# Altium schematic sheet sensor-6.SchDoc(sensor-6)
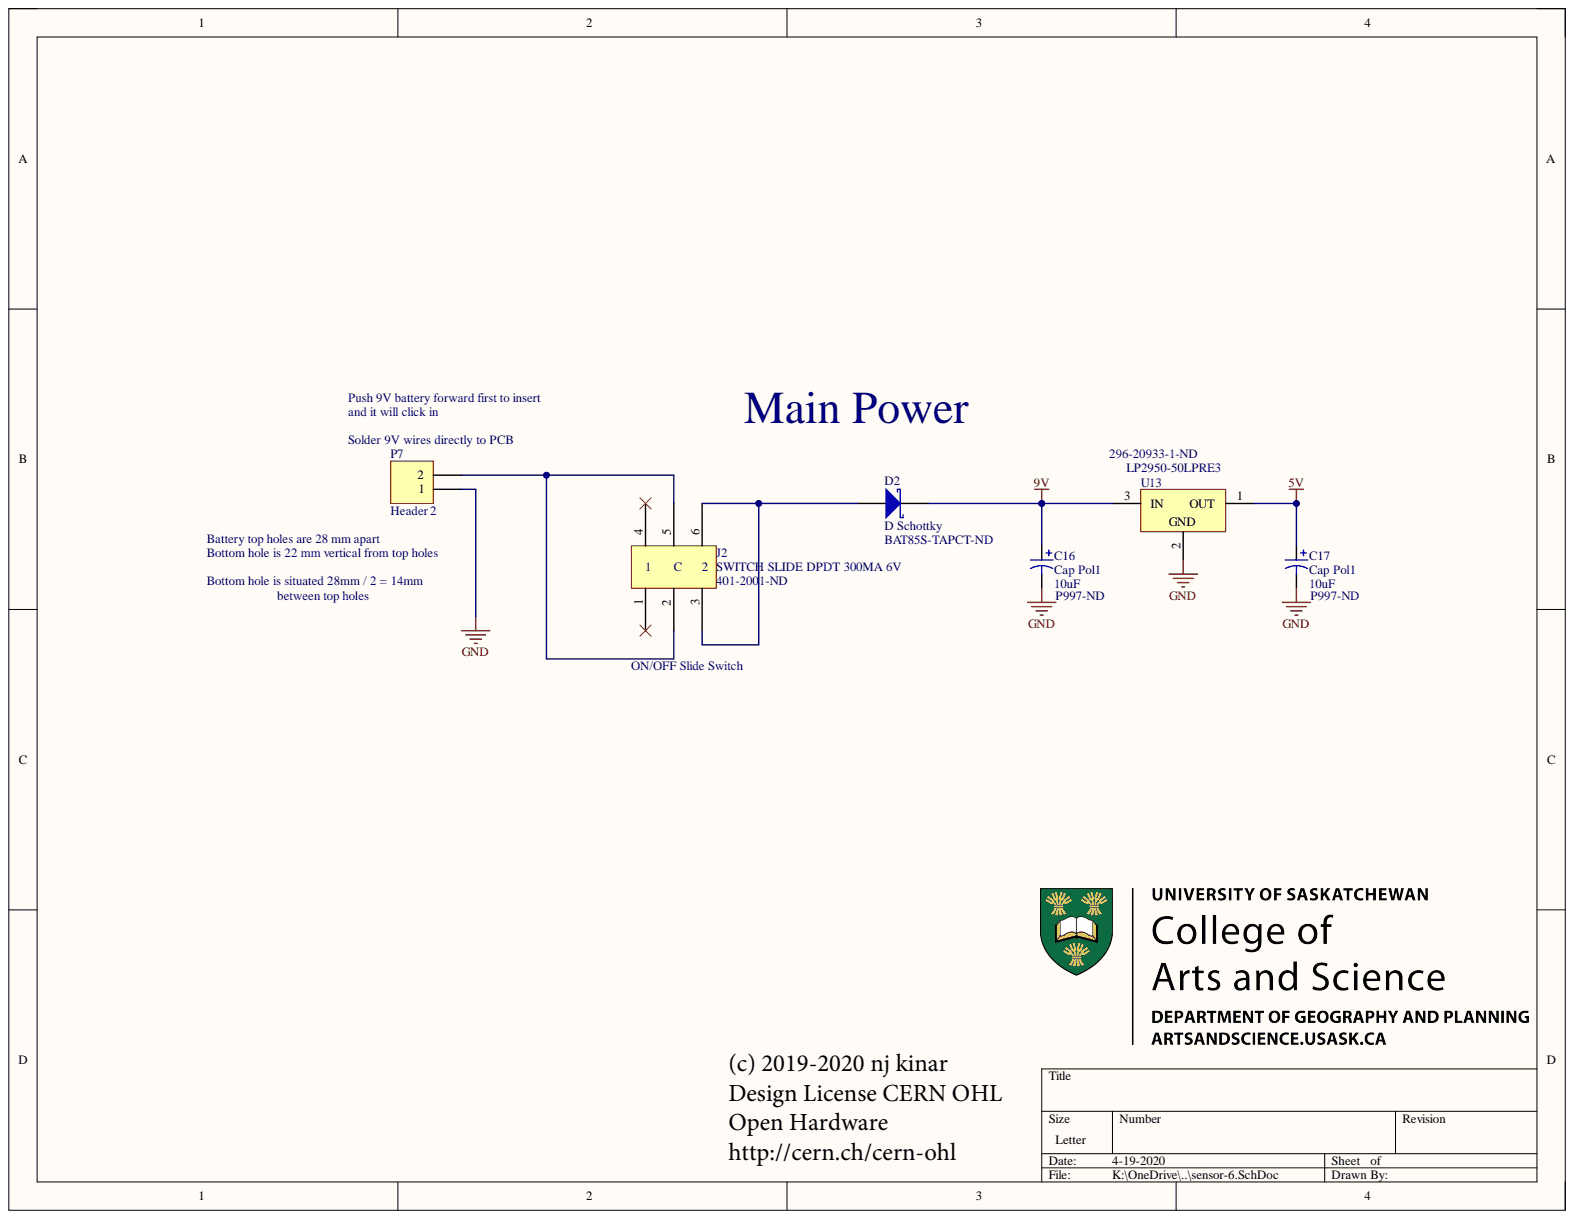
[diagram p0: sensor-6.SchDoc]

## Parts
C16  Cap Pol1  value 10uF  Capacitance: 10uF  Supplier Part Number 1: P997-ND  [ECE-A1HKS100]
C17  Cap Pol1  value 10uF  Capacitance: 10uF  Supplier Part Number 1: P997-ND  [ECE-A1HKS100]
D2  D Schottky  Supplier Part Number 1: BAT85S-TAPCT-ND  [BAT85S-TAP]
J2  SWITCH SLIDE DPDT 300MA 6V  Supplier Part Number 1: 401-2001-ND  [JS202011CQN]
P7  Header 2  [HDR1X2]
U13  LP2950-50LPRE3  [LP0003A]

## Nets
5V: C17.1 U13.1
9V: C16.1 D2.2 U13.3
GND: C16.2 C17.2 P7.1 U13.2
NetD2_1: D2.1 J2.3 J2.6
NetJ2_2: J2.2 J2.5 P7.2

Not connected: J2 1 4

## Library data of the parts
C16 C17 = Cap Pol1: Polarized Capacitor (Radial); Manufacturer: Panasonic; Lead Diameter: 0.45mm; Leakage Current: 3A; Life (Hours): 1000Hour; Polarity: Polar; Ripple Current: 35mA; Tolerance: 20%; Voltage: 50V; Case/Package: Radial; Component Kind: Standard; ComponentLink1Description: https://datasheet.ciiva.com/11778/0900766b800274c4-11778985.pdf?src-supplier=RSComponents; ComponentLink1URL: https://datasheet.ciiva.com/11778/0900766b800274c4-11778985.pdf?src-supplier=RSComponents; ComponentLink2Description: https://datasheet.ciiva.com/17536/aba0000ce15-17536597.pdf?src-supplier=Avnet+Europe; ComponentLink2URL: https://datasheet.ciiva.com/17536/aba0000ce15-17536597.pdf?src-supplier=Avnet+Europe; ComponentLink3Description: https://datasheet.ciiva.com/14594/70067878-14594328.pdf?src-supplier=Allied+Electronics; ComponentLink3URL: https://datasheet.ciiva.com/14594/70067878-14594328.pdf?src-supplier=Allied+Electronics; ComponentLink4Description: https://datasheet.ciiva.com/16205/aba0000ce15-16205737.pdf?src-supplier=Future+Electronics; ComponentLink4URL: https://datasheet.ciiva.com/16205/aba0000ce15-16205737.pdf?src-supplier=Future+Electronics; ComponentLink5Description: https://datasheet.ciiva.com/24025/a-e-461514-24025641.pdf?src-supplier=Mouser; ComponentLink5URL: https://datasheet.ciiva.com/24025/a-e-461514-24025641.pdf?src-supplier=Mouser; ComponentLink6Description: https://datasheet.ciiva.com/43459/1339471-43459545.pdf?src-supplier=Farnell; ComponentLink6URL: https://datasheet.ciiva.com/43459/1339471-43459545.pdf?src-supplier=Farnell; ComponentLink7Description: https://datasheet.ciiva.com/15166/getdatasheetpartid-169217-15166123.pdf?src-supplier=Verical; ComponentLink7URL: https://datasheet.ciiva.com/15166/getdatasheetpartid-169217-15166123.pdf?src-supplier=Verical; ComponentLink8Description: https://datasheet.ciiva.com/46397/1339471-46397117.pdf?src-supplier=Element14; ComponentLink8URL: https://datasheet.ciiva.com/46397/1339471-46397117.pdf?src-supplier=Element14; ComponentLink9Description: https://datasheet.ciiva.com/2983/aba0000ce15-2983886.pdf?src-supplier=Avnet; ComponentLink9URL: https://datasheet.ciiva.com/2983/aba0000ce15-2983886.pdf?src-supplier=Avnet; Diameter: 6.3mm; Height: 5mm; Height - Seated (Max): 0.197inch; LatestRevisionDate: 17-Jul-2002; LatestRevisionNote: Re-released for DXP Platform.; Lead Free: Lead Free; Lead Pitch: 2.5mm; Length: 5mm; Library Name: Miscellaneous Devices.IntLib; Manufacturer Part Number: ECE-A1HKS100; Max Operating Temperature: 85°C; Min Operating Temperature: -40°C; Mount: Through Hole,PCB; Number of Pins: 2; Package Quantity: 200; PackageDescription: Polarized Capacitor (Radial); 2 Leads; PackageReference: RB7.6-15; Packaging: Bulk; Pricing 1: 1=0.10000, 10=0.03000 (USD); Published: 8-Jun-2000; Radiation Hardening: No; REACH SVHC: No SVHC; Ripple Current (AC): 35mA; RoHS: Yes; Signal Integrity: Cap Pol; Simulation: CAP; Stock 1: 132836; Supplier 1: Digi-Key; Termination: Radial; Voltage Rating: 50V; Voltage Rating (DC): 50V; Weight: 0.01lb; Width: 0.5inch; Publisher: Altium Limited
D2 = D Schottky: Schottky Diode; Manufacturer: Vishay; Average Rectified Current: 200mA; Element Configuration: Single; Forward Voltage: 800mV; Max Forward Surge Current (Ifsm): 5A; Max Repetitive Reverse Voltage (Vrrm): 30V; Max Reverse Leakage Current: 2uA; Recovery Time: 5ns; Code_JEDEC: DO-214-AA; Component Kind: Standard; ComponentLink1Description: https://datasheet.ciiva.com/23785/1749004-23785297.pdf?src-supplier=Newark; ComponentLink1URL: https://datasheet.ciiva.com/23785/1749004-23785297.pdf?src-supplier=Newark; ComponentLink2Description: https://datasheet.ciiva.com/17078/bat85s-tap-17078427.pdf?src-supplier=Allied+Electronics; ComponentLink2URL: https://datasheet.ciiva.com/17078/bat85s-tap-17078427.pdf?src-supplier=Allied+Electronics; ComponentLink3Description: https://datasheet.ciiva.com/15144/getdatasheetpartid-50689-15144221.pdf?src-supplier=Verical; ComponentLink3URL: https://datasheet.ciiva.com/15144/getdatasheetpartid-50689-15144221.pdf?src-supplier=Verical; ComponentLink4Description: https://datasheet.ciiva.com/16418/bat85s-16418454.pdf?src-supplier=Future+Electronics; ComponentLink4URL: https://datasheet.ciiva.com/16418/bat85s-16418454.pdf?src-supplier=Future+Electronics; ComponentLink5Description: https://datasheet.ciiva.com/25931/bat85s-25931304.pdf?src-supplier=Avnet+Europe; ComponentLink5URL: https://datasheet.ciiva.com/25931/bat85s-25931304.pdf?src-supplier=Avnet+Europe; ComponentLink6Description: https://datasheet.ciiva.com/13950/bat85s-13950093.pdf?src-supplier=Digi-Key; ComponentLink6URL: https://datasheet.ciiva.com/13950/bat85s-13950093.pdf?src-supplier=Digi-Key; ComponentLink7Description: https://datasheet.ciiva.com/13950/bat85s-13950090.pdf?src-supplier=Digi-Key; ComponentLink7URL: https://datasheet.ciiva.com/13950/bat85s-13950090.pdf?src-supplier=Digi-Key; ComponentLink8Description: https://datasheet.ciiva.com/8283/bat85s-8283915.pdf?src-supplier=Avnet; ComponentLink8URL: https://datasheet.ciiva.com/8283/bat85s-8283915.pdf?src-supplier=Avnet; Contact Plating: Tin,Silver; Forward Current: 200mA; Height: 1.7mm; LatestRevisionDate: 17-Jul-2002; LatestRevisionNote: Re-released for DXP Platform.; Lead Free: Lead Free; Length: 3.9mm; Library Name: Miscellaneous Devices.IntLib; Manufacturer Part Number: BAT85S-TAP; Max Operating Temperature: 125°C; Max Reverse Voltage (DC): 30V; Max Surge Current: 5A; Min Operating Temperature: -65°C; Mount: Through Hole; Number of Pins: 2; Package Quantity: 10000; PackageDescription: DO-214-AA/SMB; 2 C-Bend Leads; Body 5.3 x 3.6 mm, inc. leads (LxW); PackageReference: SMB; Packaging: Ammo Pack; Peak Non-Repetitive Surge Current: 5A; Peak Reverse Current: 2uA; Pricing 1: 1=0.38000, 10=0.31000, 100=0.16440, 500=0.10816, 1000=0.07354, 2500=0.06633, 5000=0.05768 (USD); Published: 8-Jun-2000; Radiation Hardening: No; Reverse Recovery Time: 5ns; RoHS: Yes; Signal Integrity: D Schottky; Simulation: SKYDIODE; Stock 1: 54447; Supplier 1: Digi-Key; Width: 1.7mm; Publisher: Altium Limited
J2 = JS202011CQN: Manufacturer: ITT C&K; Actuator Length: 2mm; Actuator Material: Nylon,Polyamide; Actuator Type: Standard; Contact Current Rating: 100mA; Contact Resistance: 70mR; Electrical Life: 5000Cycles; Illumination Type: Non-Illuminated; Switch Function: On-On; Component Kind: Standard; ComponentLink1Description: https://datasheet.ciiva.com/5280/js-15sept15-5280375.pdf?src-supplier=Mouser; ComponentLink1URL: https://datasheet.ciiva.com/5280/js-15sept15-5280375.pdf?src-supplier=Mouser; ComponentLink2Description: https://datasheet.ciiva.com/22630/1707718-22630695.pdf?src-supplier=Element14; ComponentLink2URL: https://datasheet.ciiva.com/22630/1707718-22630695.pdf?src-supplier=Element14; ComponentLink3Description: https://datasheet.ciiva.com/8082/js-9dec14-8082522.pdf?src-supplier=Avnet; ComponentLink3URL: https://datasheet.ciiva.com/8082/js-9dec14-8082522.pdf?src-supplier=Avnet; ComponentLink4Description: https://datasheet.ciiva.com/2889/js-9dec14-2889166.pdf?src-supplier=Digi-Key; ComponentLink4URL: https://datasheet.ciiva.com/2889/js-9dec14-2889166.pdf?src-supplier=Digi-Key; Contact Material: Copper Alloy; Contact Plating: Silver; Current Rating: 300mA; Depth: 3.6mm; Description: SWITCH SLIDE DPDT 300MA 6V; Flammability Rating: UL94 V-0; Housing Material: Nylon; Lead Free: Lead Free; Length: 9mm; Manufacturer Part Number: JS202011CQN; Max Current Rating: 300mA; Max Operating Temperature: 85°C; Max Voltage Rating (DC): 6V; Min Operating Temperature: -40°C; Mount: Through Hole; Orientation: Vertical; Package Quantity: 1; Packaging: Bulk; Pricing 1: 1=0.43000, 10=0.41600, 25=0.40720, 50=0.36380, 100=0.34650, 250=0.33784, 500=0.28586, 1000=0.27720, 5000=0.24255 (USD); Radiation Hardening: No; REACH SVHC: No SVHC; RoHS: Yes; Stock 1: 30365; Supplier 1: Digi-Key; Switch Travel: 2mm; Termination: PC Pins; Throw Configuration: DPDT; Voltage Rating (DC): 6V; Library Name: CK.IntLib
P7 = Header 2: Header, 2-Pin; Component Kind: Standard; LatestRevisionDate: 17-Jul-2002; LatestRevisionNote: Re-released for DXP Platform.; Library Name: Miscellaneous Connectors.IntLib; Signal Integrity: Connector; Publisher: Altium Limited
U13 = CMP-0758-00015-2: Single Output LDO, 100 mA, Fixed 5 V Output, 5.4 to 30 V Input, 3-Pin TO-92 (LP), RoHS, Tape and Reel; Manufacturer: Texas Instruments; Accuracy: 1.4%; Accuracy (%): 1.4; Dropout Voltage: 380mV; Fixed Output Options (V): 5; Input Bias Current: 75uA; Iout (Max) (A): 0.1; Iq (Typ) (mA): 0.075; Max Input Voltage: 30V; Max Output Current: 100mA; Max Output Voltage: 5.05V; Min Input Voltage: 5.4V; Min Output Voltage: 4.95V; Min Supply Voltage: 2V; Nominal Output Voltage: 5V; Number of Outputs: 1; Output Capacitor Type: Non-Ceramic; Output Options: Fixed Output; Polarity: Positive; Rating: Catalog; Vdo (Typ) (mV): 380; Vin (Max) (V): 30; Vin (Min) (V): 5.4; Vout (Max) (V): 5.05; Vout (Min) (V): 4.95; Case/Package: TO-92; Component Kind: Standard; ComponentLink1Description: Manufacturer URL; ComponentLink1URL: http://www.ti.com/; ComponentLink2Description: Datasheet; ComponentLink2URL: http://www.ti.com/lit/ds/symlink/lp2950-30.pdf; ComponentLink3Description: Package Specification; ComponentLink3URL: http://www.ti.com/lit/ml/msot002c/msot002c.pdf; ComponentLink4Description: https://datasheet.ciiva.com/2041/lp2951-2041968.pdf?src-supplier=Digi-Key; ComponentLink4URL: https://datasheet.ciiva.com/2041/lp2951-2041968.pdf?src-supplier=Digi-Key; ComponentLink5Description: https://datasheet.ciiva.com/3223/lp2951-33-3223814.pdf?src-supplier=Avnet; ComponentLink5URL: https://datasheet.ciiva.com/3223/lp2951-33-3223814.pdf?src-supplier=Avnet; ComponentLink6Description: https://datasheet.ciiva.com/1511/1864445-1511850.pdf?src-supplier=Element14; ComponentLink6URL: https://datasheet.ciiva.com/1511/1864445-1511850.pdf?src-supplier=Element14; ComponentLink7Description: https://datasheet.ciiva.com/46448/1445037-46448763.pdf?src-supplier=Element14; ComponentLink7URL: https://datasheet.ciiva.com/46448/1445037-46448763.pdf?src-supplier=Element14; ComponentLink8Description: https://datasheet.ciiva.com/2041/lp2951-2041966.pdf?src-supplier=Digi-Key; ComponentLink8URL: https://datasheet.ciiva.com/2041/lp2951-2041966.pdf?src-supplier=Digi-Key; ComponentLink9Description: https://datasheet.ciiva.com/2041/lp2951-2041967.pdf?src-supplier=Digi-Key; ComponentLink9URL: https://datasheet.ciiva.com/2041/lp2951-2041967.pdf?src-supplier=Digi-Key; Contact Plating: Tin,Matte; DatasheetVersion: SLVS582H; Height: 3.68mm; Lead Free: Lead Free; Length: 4.83mm; Library Name: Altium Content Vault; Manufacturer Part Number: LP2950-50LPRE3; Max Operating Temperature: 125°C; Min Operating Temperature: -40°C; Mounting Technology: Through Hole; Number of Pins: 3; Number of Regulators: 1; Output Current: 100mA; Output Type: Fixed; Output Voltage: 5V; Output Voltage Accuracy: 1.4%; Package Quantity: 1; PackageDescription: 3-Pin Plastic Cylindrical Package, Body Dia 4.825 mm, Pitch 2.65 mm; PackageReference: LP0003A; PackageVersion: 4040001-2/E; Packaging: Tape and Reel; Packing: Tape and Reel; PartNumber: LP2950-50LPRE3; Pricing 1: 1=0.47000, 10=0.40200, 100=0.29990, 500=0.23566, 1000=0.18210 (USD); Quiescent Current: 75uA; Radiation Hardening: No; REACH SVHC: No SVHC; RoHS: TRUE; Stock 1: 99647; Supplier 1: Digi-Key; Supplier Part Number 1: 296-20933-1-ND; Termination: Through Hole; Width: 3.43mm
